# Altium schematic sheet KAP140.SchDoc
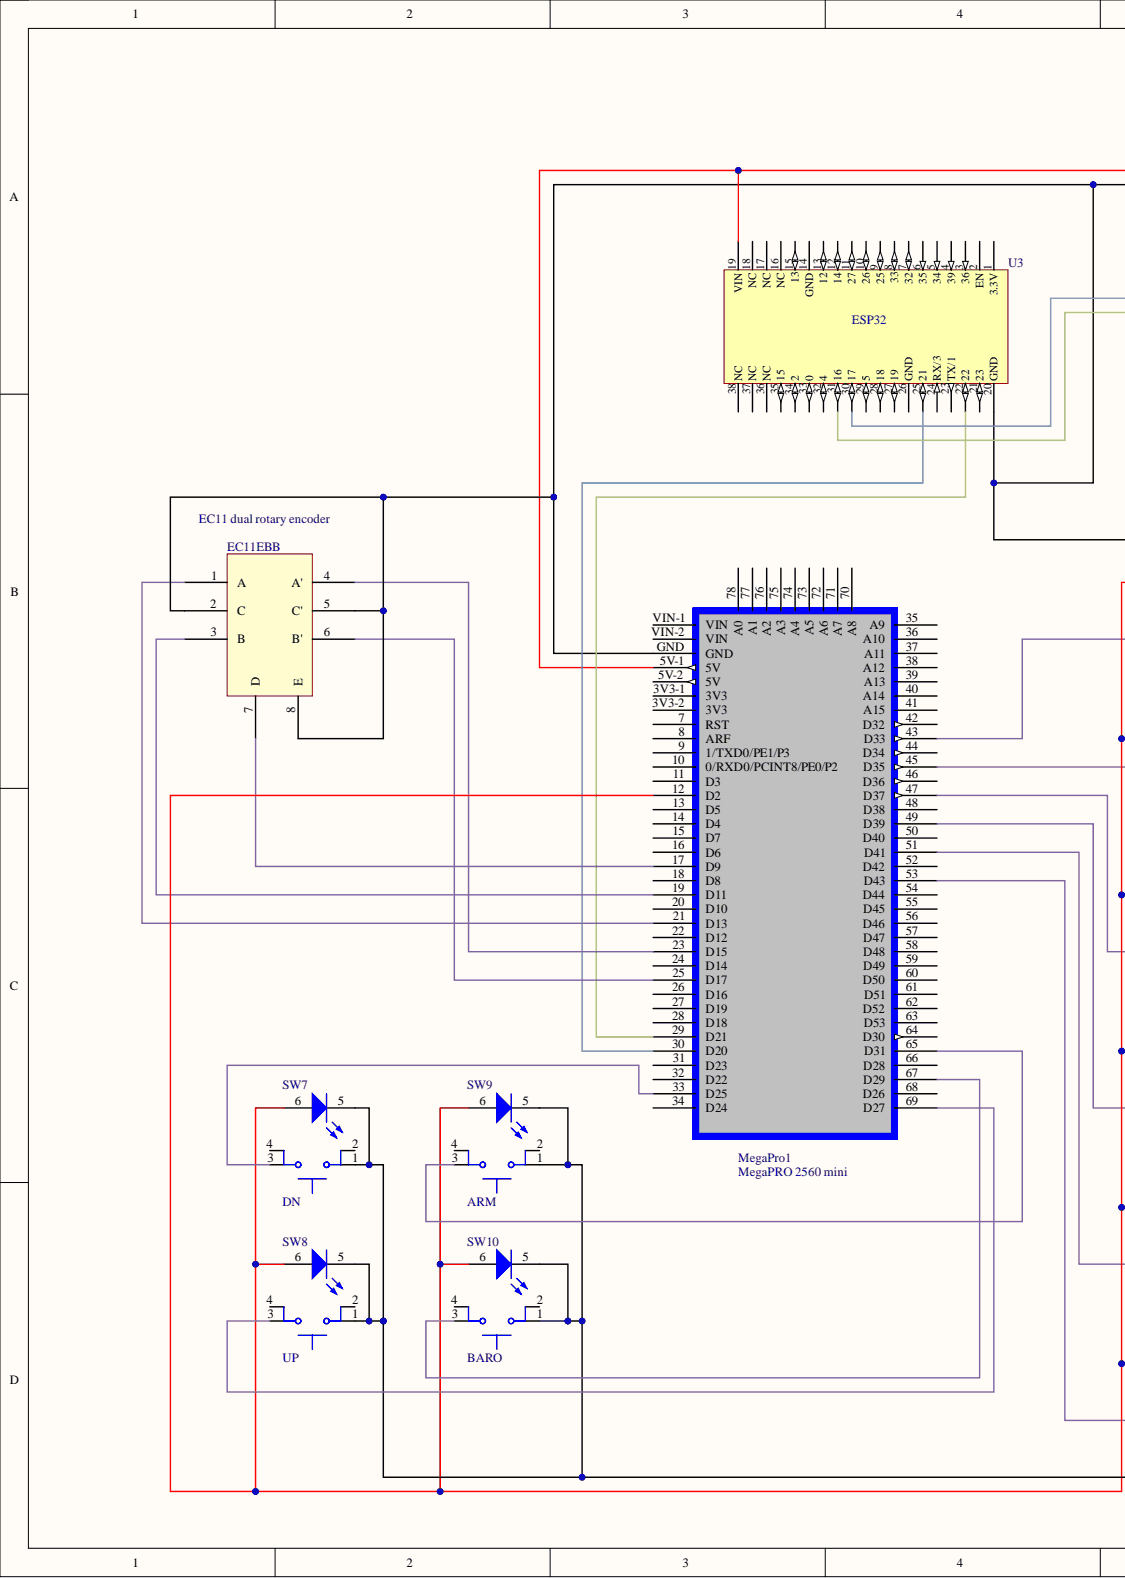
[diagram p0: KAP140.SchDoc, left]
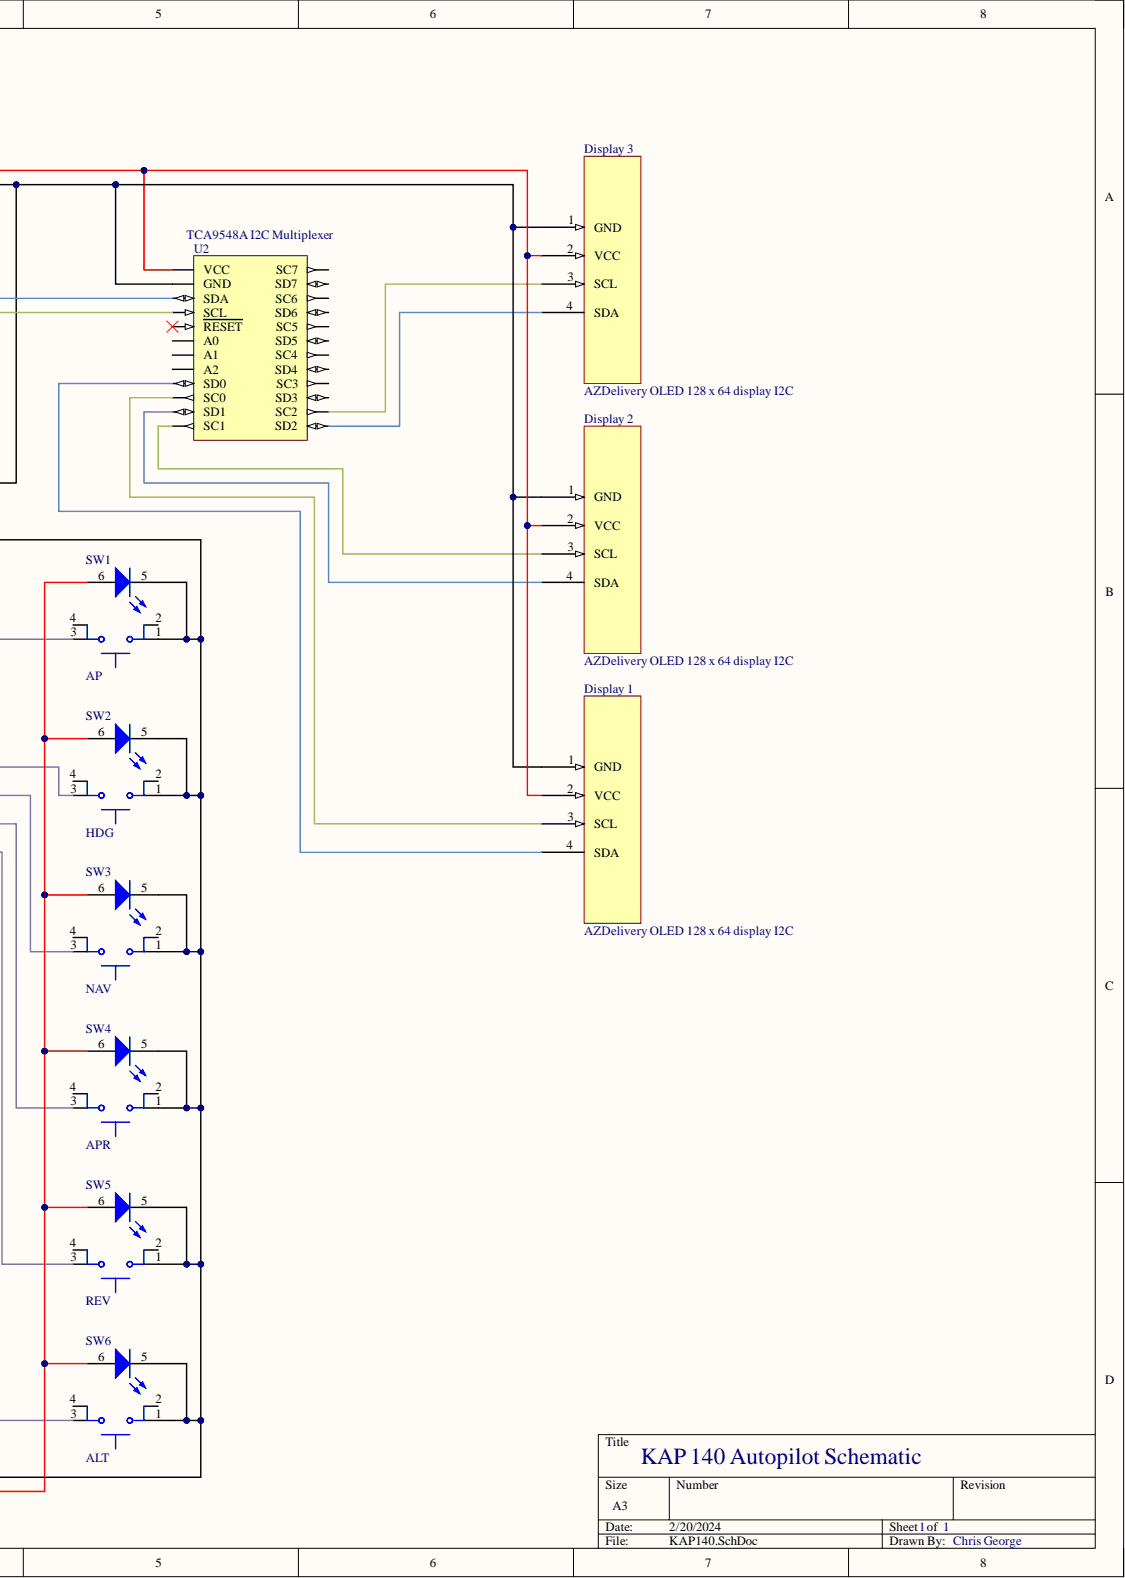
[diagram p1: KAP140.SchDoc, right]

## Parts
Display_1  AZDelivery OLED 128 x 64 display I2C  [PCB-fl1vq74gfog5umdmp3kk-1]
Display_2  AZDelivery OLED 128 x 64 display I2C  [PCB-fl1vq74gfog5umdmp3kk-1]
Display_3  AZDelivery OLED 128 x 64 display I2C  [PCB-fl1vq74gfog5umdmp3kk-1]
MegaPro1  MegaPRO 2560 mini  [PCB-7szv4g37040gsalau7nj-1]
RotaryEncoder  EC11 dual rotary encoder  [PCB-r38anxiy8jv6e85u5l87-1]
SW1  AP  [PCB-3ql1m5om20mhl2lum3d9-1]
SW2  HDG  [PCB-3ql1m5om20mhl2lum3d9-1]
SW3  NAV  [PCB-3ql1m5om20mhl2lum3d9-1]
SW4  APR  [PCB-3ql1m5om20mhl2lum3d9-1]
SW5  REV  [PCB-3ql1m5om20mhl2lum3d9-1]
SW6  ALT  [PCB-3ql1m5om20mhl2lum3d9-1]
SW7  DN  [PCB-3ql1m5om20mhl2lum3d9-1]
SW8  UP  [PCB-3ql1m5om20mhl2lum3d9-1]
SW9  ARM  [PCB-3ql1m5om20mhl2lum3d9-1]
SW10  BARO  [PCB-3ql1m5om20mhl2lum3d9-1]
U2  TCA9548A I2C Multiplexer  Manufacturer Part Number: TCA9548A  [PCB-c38rd6ur12yqrg3kq9hd-1]
U3  ESP32  [OLM32-ESPS1-Footprint-1]

## Nets
NetDisplay 1_1: Display_1.1(GND) Display_2.1(GND) Display_3.1(GND) MegaPro1.GND RotaryEncoder.2(C) RotaryEncoder.5(C') RotaryEncoder.8(E) SW1.1 SW1.5 SW2.1 SW2.5 SW3.1 SW3.5 SW4.1 SW4.5 SW5.1 SW5.5 SW6.1 SW6.5 SW7.1 SW7.5 SW8.1 SW8.5 SW9.1 SW9.5 SW10.1 SW10.5 U2.2(GND) U3.20(GND)
NetDisplay 1_2: Display_1.2(VCC) Display_2.2(VCC) Display_3.2(VCC) MegaPro1.5V-1(5V) U2.1(VCC) U3.19(VIN)
NetDisplay 1_3: Display_1.3(SCL) U2.10(SC0)
NetDisplay 1_4: Display_1.4(SDA) U2.9(SD0)
NetDisplay 2_3: Display_2.3(SCL) U2.12(SC1)
NetDisplay 2_4: Display_2.4(SDA) U2.11(SD1)
NetDisplay 3_3: Display_3.3(SCL) U2.14(SC2)
NetDisplay 3_4: Display_3.4(SDA) U2.13(SD2)
NetMegaPro1_12: MegaPro1.12(D2) SW1.6 SW2.6 SW3.6 SW4.6 SW5.6 SW6.6 SW7.6 SW8.6 SW9.6 SW10.6
NetMegaPro1_17: MegaPro1.17(D9) RotaryEncoder.7(D)
NetMegaPro1_19: MegaPro1.19(D11) RotaryEncoder.3(B)
NetMegaPro1_21: MegaPro1.21(D13) RotaryEncoder.1(A)
NetMegaPro1_23: MegaPro1.23(D15) RotaryEncoder.4(A')
NetMegaPro1_25: MegaPro1.25(D17) RotaryEncoder.6(B')
NetMegaPro1_29: MegaPro1.29(D21) U3.22
NetMegaPro1_30: MegaPro1.30(D20) U3.25
NetMegaPro1_33: MegaPro1.33(D25) SW7.3
NetMegaPro1_43: MegaPro1.43(D33) SW1.3
NetMegaPro1_45: MegaPro1.45(D35) SW2.3
NetMegaPro1_47: MegaPro1.47(D37) SW3.3
NetMegaPro1_49: MegaPro1.49(D39) SW4.3
NetMegaPro1_51: MegaPro1.51(D41) SW5.3
NetMegaPro1_53: MegaPro1.53(D43) SW6.3
NetMegaPro1_65: MegaPro1.65(D31) SW9.3
NetMegaPro1_67: MegaPro1.67(D29) SW10.3
NetMegaPro1_69: MegaPro1.69(D27) SW8.3
NetU2_3: U2.3(SDA) U3.30
NetU2_4: U2.4(SCL) U3.31

Not connected: MegaPro1 3V3-1(3V3) 3V3-2(3V3) 5V-2(5V) 7(RST) 8(ARF) 9(1/TXD0/PE1/P3) 10(0/RXD0/PCINT8/PE0/P2) 11(D3) 13(D5) 14(D4) 15(D7) 16(D6) 18(D8) 20(D10) 22(D12) 24(D14) 26(D16) 27(D19) 28(D18) 31(D23) 32(D22) 34(D24) 35(A9) 36(A10) 37(A11) 38(A12) 39(A13) 40(A14) 41(A15) 42(D32) 44(D34) 46(D36) 48(D38) 50(D40) 52(D42) 54(D44) 55(D45) 56(D46) 57(D47) 58(D48) 59(D49) 60(D50) 61(D51) 62(D52) 63(D53) 64(D30) 66(D28) 68(D26) 70(A8) 71(A7) 72(A6) 73(A5) 74(A4) 75(A3) 76(A2) 77(A1) 78(A0) VIN-1(VIN) VIN-2(VIN); SW1 2 4; SW2 2 4; SW3 2 4; SW4 2 4; SW5 2 4; SW6 2 4; SW7 2 4; SW8 2 4; SW9 2 4; SW10 2 4; U2 5(RESET) 6(A0) 7(A1) 8(A2) 15(SD3) 16(SC3) 17(SD4) 18(SC4) 19(SD5) 20(SC5) 21(SD6) 22(SC6) 23(SD7) 24(SC7); U3 1(3.3V) 2(EN) 3 4 5 6 7 8 9 10 11 12 13 14(GND) 15 16(NC) 17(NC) 18(NC) 21 23(TX/1) 24(RX/3) 26(GND) 27 28 29 32 33 34 35 36(NC) 37(NC) 38(NC)

## Library data of the parts
Display_1-Display_3 = CMP-1dced5014d12c209-15: 128x64 OLED Display I2C; Component Kind: Standard; Library Name: My Personal Space; OctopartID: 1dced5014d12c209
MegaPro1 = CMP-1f22d28abc09c0e5-7: ATMegaPRO2560mini; Manufacturer Part Number: ATMEGA2560R212-AU; Component Kind: Standard; Library Name: My Personal Space; Lifecycle Status: ROBOTDYN; Octopart URL: https://octopart.com/atmega2560r212-au-microchip-77760077; OctopartID: 1f22d28abc09c0e5
RotaryEncoder = CMP-19b7d0b6b3c8c670-2: Mechanical Encoder Rotary Incremental Flat 10mN.m Straight Quadrature Digital Square Wave 15PPR Through Hole PC Pin; Manufacturer Part Number: EC11EBB24C03; Number of Detents: 30; Supply Voltage (DC): 5.00 V (max); Component Kind: Standard; Library Name: My Personal Space; Mounting Style: Through Hole; Octopart URL: https://octopart.com/ec11ebb24c03-alps-757529; OctopartID: 19b7d0b6b3c8c670; REACH SVHC Compliance: No SVHC; RoHS: Compliant
SW1-SW10 = CMP-4921811e10c396e6-1: Illuminated Tactile Switch, SPST, 50MA, 12VDC; Manufacturer Part Number: TL1265YQSCLR; Lead-Free Status: Lead Free; Component Kind: Standard; Library Name: My Personal Space; Mounting Style: PC Board, Through Hole; Octopart URL: https://octopart.com/tl1265yqsclr-e-switch-20282500; OctopartID: 4921811e10c396e6; Packaging: Bulk; RoHS: Compliant
U2 = CMP-2636eaed500ff9f1-8: Chinese I2C Multiplexer TCA9548A. Differ from Adafruit since it is larger that it by 2.54mm; Component Kind: Standard; Library Name: My Personal Space; OctopartID: 2636eaed500ff9f1
U3 = CMP-a49e6be724a57b59-23: ESP32-S1 MCU; Component Kind: Standard; Library Name: My Personal Space; OctopartID: a49e6be724a57b59
